# Altium schematic sheet Carte_Alim_TOP.SchDoc(Carte_Alim_TOP)
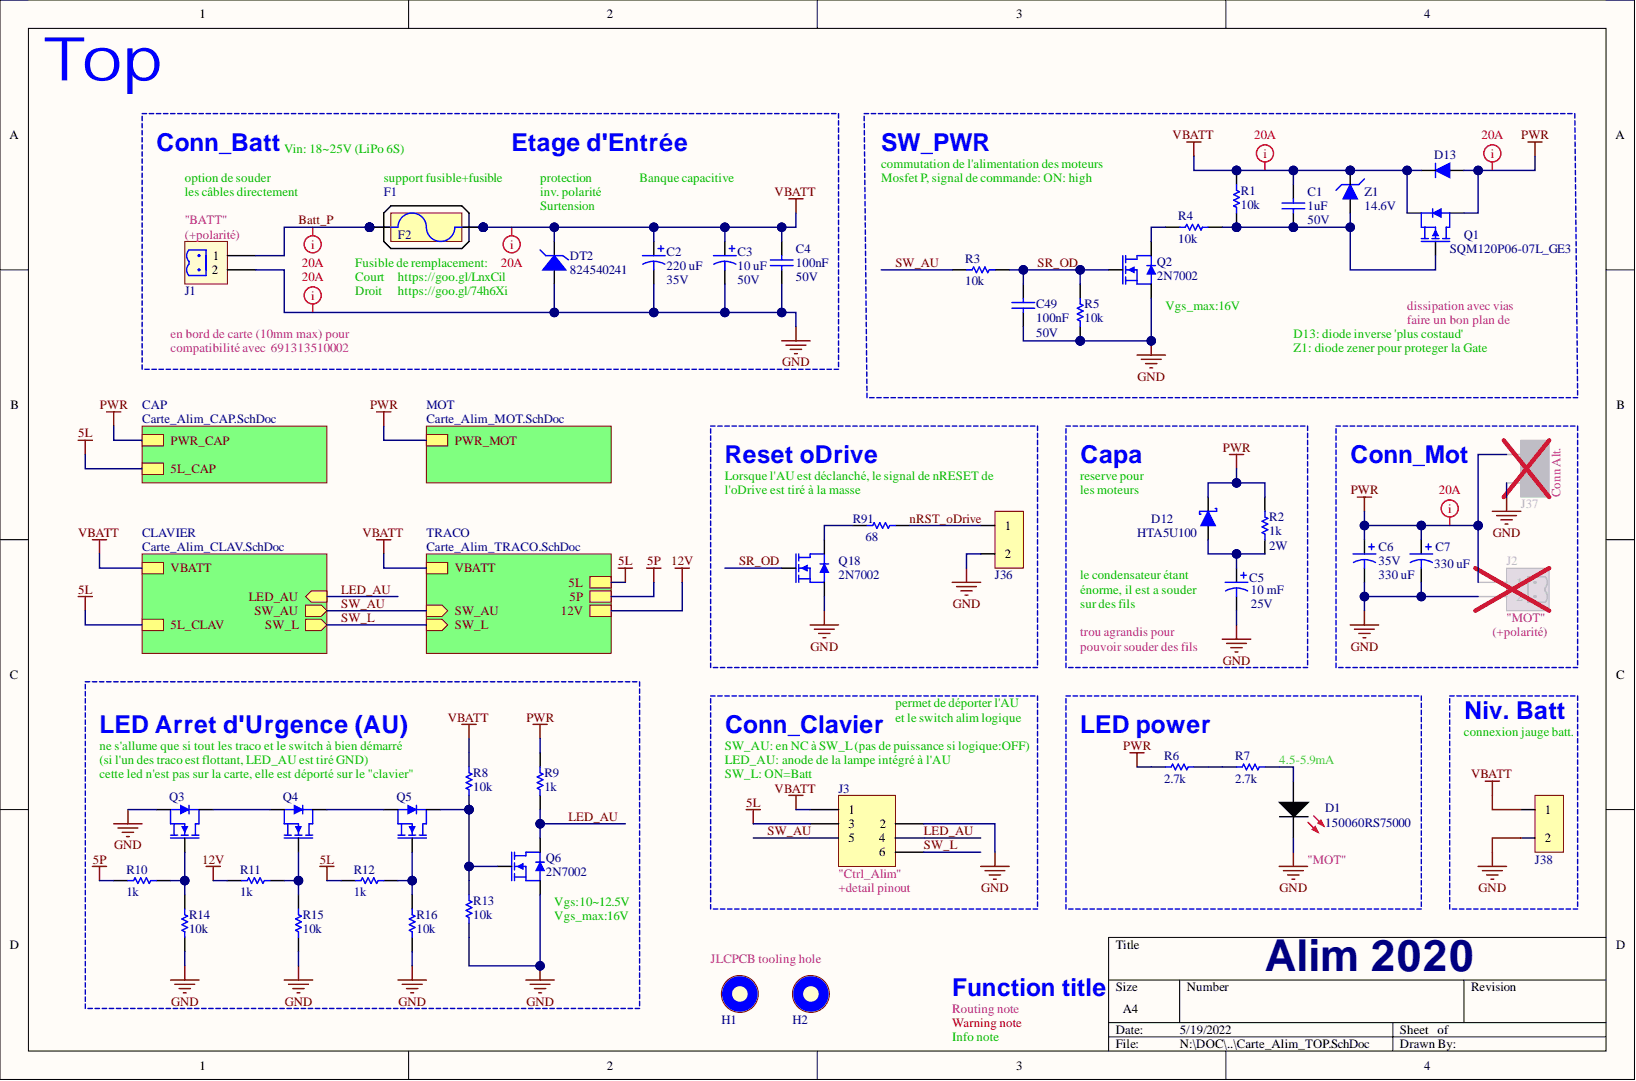
[diagram p0: Carte_Alim_TOP.SchDoc]

## Parts
C1  1uF  RatedVoltage: 50V  [WCAP-CSGP_0805, 2x1.25x1.25]
C2  220 uF  RatedVoltage: 35V  [WCAP-ATG8_8x11.5x3.5x0.5]
C3  10 uF  RatedVoltage: 50V  [WCAP-ATG8_5x11x2x0.5]
C4  100nF  RatedVoltage: 50V  [WCAP-CSGP_0603, 1.6x0.8x0.8]
C5  10 mF  RatedVoltage: 25V  [WCAP-ATG8_22x41x10x0.8]
C6  330 uF  RatedVoltage: 35V  [WCAP-ATG8_10x12.5x5x0.6]
C7  330 uF  [WCAP-ATG8_10x12.5x5x0.6]
C49  100nF  RatedVoltage: 50V  [WCAP-CSGP_0603, 1.6x0.8x0.8]
D1  150060RS75000  [SMCW_0603]
D12  HTA5U100  [SMA, DO-214AC]
D13  RS3J R6  [SMC, DO-214AB]  (value not drawn)
DT2  824540241  [SMC, DO-214AB]
F1  3557-2  [3557-2]  (value not drawn)
F2  0891030.NXS  (value not drawn)  (mechanical)
H1  1.152mm  [Tooling_hole_JLCPCB]  (value not drawn)  (no BOM)
H2  1.152mm  [Tooling_hole_JLCPCB]  (value not drawn)  (no BOM)
J1  value 2  [691311500102]  (hidden comment: 691311500102)
J2  value 2  [691311500102]  (hidden comment: 691311500102)
J3  value 6  [690367280676]  (hidden comment: 690367280676)
J36  22-03-2021  [22-03-2021]  (value not drawn)
J37  22-03-2021  [22-03-2021]  (value not drawn)
J38  22-03-2021  [22-03-2021]  (value not drawn)
Q1  SQM120P06-07L_GE3  [TO263AB, D2PAK]
Q2  2N7002  [SOT-23]
Q3  2N7002  [SOT-23]  (value not drawn)
Q4  2N7002  [SOT-23]  (value not drawn)
Q5  2N7002  [SOT-23]  (value not drawn)
Q6  2N7002  [SOT-23]
Q18  2N7002  [SOT-23]
R1  value 10k  [RESC1608X06N]  (hidden comment: RC0603FR-0710KL)
R2  1k  Power: 2W  [RESADV480W80L1200D390]
R3  value 10k  [RESC1608X06N]  (hidden comment: RC0603FR-0710KL)
R4  value 10k  [RESC1608X06N]  (hidden comment: RC0603FR-0710KL)
R5  value 10k  [RESC1608X06N]  (hidden comment: RC0603FR-0710KL)
R6  value 2.7k  [RESC2012X06N]  (hidden comment: RC0805FR-072K7L)
R7  value 2.7k  [RESC2012X06N]  (hidden comment: RC0805FR-072K7L)
R8  value 10k  [RESC1608X06N]  (hidden comment: RC0603FR-0710KL)
R9  value 1k  [RESC1608X06N]  (hidden comment: RC0603FR-071KL)
R10  value 1k  [RESC1608X06N]  (hidden comment: RC0603FR-071KL)
R11  value 1k  [RESC1608X06N]  (hidden comment: RC0603FR-071KL)
R12  value 1k  [RESC1608X06N]  (hidden comment: RC0603FR-071KL)
R13  value 10k  [RESC1608X06N]  (hidden comment: RC0603FR-0710KL)
R14  value 10k  [RESC1608X06N]  (hidden comment: RC0603FR-0710KL)
R15  value 10k  [RESC1608X06N]  (hidden comment: RC0603FR-0710KL)
R16  value 10k  [RESC1608X06N]  (hidden comment: RC0603FR-0710KL)
R91  value 68  [RESC1608X06N]  (hidden comment: RC0603FR-0768RL)
Z1  value 14.6V  [SOD-323]  (hidden comment: MM3Z15VST1G)

## Nets
5L: J3.3 R12.2
5P: R10.2
12V: R11.2
GND: C2.2 C3.2 C4.1 C5.2 C6.2 C7.2 C49.1 D1.1 DT2.1 J1.2 J2.2 J3.2 J36.2 J37.2 J38.2 Q2.2 Q3.2 Q6.2 Q18.2 R5.2 R13.1 R14.1 R15.1 R16.1
PWR: C6.1 C7.1 D12.2 D13.1 J2.1 J37.1 Q1.4 R2.1 R6.1 R9.2
VBATT: C1.2 C2.1 C3.1 C4.2 D13.2 DT2.2 F1.2 F1.4 J3.1 J38.1 Q1.3 R1.2 R8.2 Z1.2
Batt_P: F1.1 F1.3 J1.1
LED_AU: J3.4 Q6.3 R9.1
nRST_oDrive: J36.1 R91.2
SR_OD: C49.2 Q2.1 Q18.1 R3.2 R5.1
SW_AU: J3.5 R3.1
SW_L: J3.6
NetC1_1: C1.1 Q1.1 R1.1 R4.1 Z1.1
NetC5_1: C5.1 D12.1 R2.2
NetD1_2: D1.2 R7.1
NetQ2_3: Q2.3 R4.2
NetQ3_1: Q3.1 R10.1 R14.2
NetQ3_3: Q3.3 Q4.2
NetQ4_1: Q4.1 R11.1 R15.2
NetQ4_3: Q4.3 Q5.2
NetQ5_1: Q5.1 R12.1 R16.2
NetQ5_3: Q5.3 Q6.1 R8.1 R13.2
NetQ18_3: Q18.3 R91.1
NetR6_2: R6.2 R7.2

## Library data of the parts
C1 = WCAP-CSGP, 885012207103: CAP CER 1uF 50V X7R 0805; Manufacturer: Wurth Elektronik; CeramicType: X7R; Component Kind: Standard; ComponentLink1Description: Datasheet; ComponentLink1URL: http://katalog.we-online.com/pbs/datasheet/885012207103.pdf; Manufacturer Part Number: 885012207103; Size: 0805; Supplier 2: JLCPCB; Supplier Footprint 2: 0805; Supplier Part Number 2: C28323; Value: 1uF; Library Name: Condensateur.IntLib
C2 = WCAP-ATG8, 860010574011: CAP Alu 220 UF 20% 35 V; Manufacturer: Wurth Elektronik; Component Kind: Standard; ComponentLink1Description: Datasheet; ComponentLink1URL: http://katalog.we-online.de/pbs/datasheet/860010574011.pdf; Manufacturer Part Number: 860010574011; Size: 8mmx11.5mm; Value: 220 uF; Library Name: Condensateur.IntLib
C3 = WCAP-ATG8, 860010672009: CAP Alu 10 UF 20% 50 V; Manufacturer: Wurth Elektronik; Component Kind: Standard; ComponentLink1Description: Datasheet; ComponentLink1URL: http://katalog.we-online.de/pbs/datasheet/860010672009.pdf; Manufacturer Part Number: 860010672009; Size: 5mmx11mm; Value: 10 uF; Library Name: Condensateur.IntLib
C4 C49 = WCAP-CSGP, 885012206095: CAP CER 100nF 50V X7R 0603; Manufacturer: Wurth Elektronik; CeramicType: X7R; Component Kind: Standard; ComponentLink1Description: Datasheet; ComponentLink1URL: http://katalog.we-online.com/pbs/datasheet/885012206095.pdf; Manufacturer Part Number: 885012206095; Size: 0603; Supplier 2: JLCPCB; Supplier Footprint 2: 0603; Supplier Part Number 2: C14663; Value: 100nF; Library Name: Condensateur.IntLib
C5 = WCAP-ATG8, 860010483029: CAP Alu 10'000 UF 25 V; Manufacturer: Wurth Elektronik; Component Kind: Standard; ComponentLink1Description: Datasheet; ComponentLink1URL: http://katalog.we-online.de/pbs/datasheet/860010483029.pdf; Manufacturer Part Number: 860010483029; Size: 22x41mm; Value: 10 mF; Library Name: Condensateur.IntLib
C6 = WCAP-ATG8, 860010575012: CAP Alu 330 UF 20% 35 V; Manufacturer: Wurth Elektronik; Component Kind: Standard; ComponentLink1Description: Datasheet; ComponentLink1URL: http://katalog.we-online.de/pbs/datasheet/860010575012.pdf; Manufacturer Part Number: 860010575012; Size: 10mmx12.5mm; Value: 330 uF; Library Name: Condensateur.IntLib
C7 = WCAP-ATG8, 860010575012: CAP Alu 330 UF 20% 35 V; Manufacturer: Wurth Elektronik; RatedVoltage: 35V; Component Kind: Standard; ComponentLink1Description: Datasheet; ComponentLink1URL: http://katalog.we-online.de/pbs/datasheet/860010575012.pdf; Manufacturer Part Number: 860010575012; Size: 10mmx12.5mm; Value: 330 uF; Library Name: Condensateur.IntLib
D1 = WL-SMCW 0603  150060RS75000: SMD mono-color Chip LED, WL-SMCW, Red; Manufacturer: Wurth Elektronik; Value: Rouge; Component Kind: Standard; ComponentLink1Description: Datasheet; ComponentLink1URL: http://katalog.we-online.com/led/datasheet/150060RS75000.pdf; Library Name: Opto.IntLib; Manufacturer Part Number: 150060RS75000; Supplier 1: Farnell; Supplier 2: JLCPCB; Supplier Footprint 2: LED_0603; Supplier Part Number 1: 2322071; Supplier Part Number 2: C2286
D12 = HTA5U100: DIODE SCHOTTKY 100V 5A SMA (DO-214AC); Manufacturer: MULTICOMP; ContinuousForwardCurrent: 5A (Pk 50A); Component Kind: Standard; ComponentLink1Description: Datasheet; ComponentLink1URL: http://www.farnell.com/datasheets/2282884.pdf; Library Name: Diode.IntLib; Manufacturer Part Number: HTA5U100; Supplier 1: Farnell; Supplier Part Number 1: 2750942; Type: Schottky; Value: 100V
D13 = RS3J_R6: DIODE GEN PURP 600V 3A SMC; Manufacturer: TAIWAN SEMICONDUCTOR; Type: Standard; Component Kind: Standard; ComponentLink1Description: Datasheet; ComponentLink1URL: http://www.farnell.com/datasheets/2182655.pdf; ContinuousForwardCurrent: 3A; Library Name: Diode.IntLib; Manufacturer Part Number: RS3J R6; Supplier 1: Farnell; Supplier Part Number 1: 2677388; Value: 600V
DT2 = 824540241: WE-TVSP Power TVS Diode, Unidirectional, 1500 W, 24 VDC; Manufacturer: Wurth Electronics Inc.; Value: 24V; Component Kind: Standard; ComponentLink1Description: Datasheet; ComponentLink1URL: https://www.we-online.com/catalog/datasheet/824540241.pdf; Library Name: Diode.IntLib; Manufacturer Part Number: 824540241; Supplier 1: Farnell; Supplier Part Number 1: 2536699; Type: TVS
F1 = 3557-2: FUSE Holder 500V 30A PCB; Manufacturer: Keystone Electronics; Value: na; Component Kind: Standard; ComponentLink1Description: Datasheet; ComponentLink1URL: http://www.keyelco.com/product-pdf.cfm?p=296; Library Name: Fuse.IntLib; Manufacturer Part Number: 3557-2; Supplier 1: Farnell; Supplier Part Number 1: 2292904
F2 = 0891030.NXS: FUSE AUTO 30A 58VDC BLADE MINI; Manufacturer: Littelfuse Inc.; ComponentLink1Description: Datasheet; ComponentLink1URL: http://www.littelfuse.com/~/media/automotive/datasheets/fuses/passenger-car-and-commercial-vehicle/blade-fuses/littelfuse_lowprofilemini_datasheet.pdf; Library Name: Fuse.IntLib; Manufacturer Part Number: 0891030.NXS; Supplier 1: Digi-Key; Supplier Part Number 1: F4993-ND; Value: 30A
H1 H2 = HOLE_TOOLING: Tooling hole pour JLCPCB assembly; ComponentLink1Description: Manufacturer; ComponentLink1URL: https://support.jlcpcb.com/article/92-how-to-add-tooling-holes-for-smt-assembly-order; Library Name: Divers.IntLib
J1 J2 = 691311500102: WR-TBL 2p Series 311 - 5.08 mm Closed Vertical PCB Header; Manufacturer: Wurth Electronics Inc.; Component Kind: Standard; ComponentLink1Description: Datasheet; ComponentLink1URL: https://katalog.we-online.de/em/datasheet/6913115001xx.pdf; Manufacturer Part Number: 691311500102; Supplier 1: Farnell; Supplier Part Number 1: 1641978; Library Name: Connecteurs.IntLib
J3 = 690367280676: WR-MM 6p Female SMT Connector with Polarization; Manufacturer: Wurth Electronics Inc.; Component Kind: Standard; ComponentLink1Description: Datasheet; ComponentLink1URL: http://katalog.we-online.de/em/datasheet/69036728xx76.pdf; Manufacturer Part Number: 690367280676; Supplier 1: Farnell; Supplier Part Number 1: 1641849; Library Name: Connecteurs.IntLib
J36-J38 = 22-03-2021: CONN HEADER VERT 2POS 2.54MM; Manufacturer: MOLEX; Component Kind: Standard; ComponentLink1Description: Datasheet; ComponentLink1URL: http://www.farnell.com/datasheets/1791308.pdf; ComponentLink2Description: Drawings; ComponentLink2URL: http://www.farnell.com/cad/495071.pdf  http://www.farnell.com/cad/1533907.pdf  http://www.farnell.com/cad/1533907.pdf; Manufacturer Part Number: 22-03-2021; Supplier 1: Farnell; Supplier Part Number 1: 9731075; Library Name: Connecteurs.IntLib
Q1 = SQM120P06-07L_GE3: MOSFET P 60V 120A D2PAK; Manufacturer: VISHAY; Component Kind: Standard; ComponentLink1Description: Datasheet; ComponentLink1URL: http://www.vishay.com/docs/67026/sqm120p0.pdf; Library Name: Transistors.IntLib; Manufacturer Part Number: SQM120P06-07L_GE3; Supplier 1: Farnell; Supplier Part Number 1: 2617099
Q2-Q6 Q18 = 2N7002: MOSFET N 60V 115MA SOT23; Manufacturer: Changjiang Electronics Tech (CJ); Component Kind: Standard; ComponentLink1Description: Datasheet; ComponentLink1URL: https://datasheet.lcsc.com/szlcsc/1810151612_Changjiang-Electronics-Tech-CJ-2N7002_C8545.pdf; Library Name: Transistors.IntLib; Manufacturer Part Number: 2N7002; Supplier 1: Farnell; Supplier 2: JLCPCB; Supplier Footprint 2: SOT-23-3; Supplier Part Number 1: 1510761; Supplier Part Number 2: C8545
R1 R3-R5 R8 R13-R16 = RC0603FR-0710KL: RES SMD 10K OHM 1% 1/10W 0603; Manufacturer: Yageo; Power: 100mW; Vmax: 50V; Component Kind: Standard; ComponentLink1Description: Manufacturer; ComponentLink1URL: http://www.yageo.com/NewPortal/_en/index.jsp; ComponentLink2Description: Datasheet; ComponentLink2URL: http://www.farnell.com/datasheets/2167052.pdf; Manufacturer Part Number: RC0603FR-0710KL; Precision: ±1%; Supplier 1: Farnell; Supplier 2: JLCPCB; Supplier Footprint 2: 0603; Supplier Part Number 1: 9238603; Supplier Part Number 2: C25804; Type: Thick Film; Library Name: Resistance.IntLib
R2 = Generic_TH_Vert_Res: resistance traversante verticale générique; Manufacturer: MULTICOMP; Manufacturer Part Number: MCKNP02WJ0102A19; Precision: ±5%; Component Kind: Standard; Library Name: Resistance.IntLib; Supplier 1: Farnell; Supplier Part Number 1: 1903754; Type: Through Hole; Value: 1k
R6 R7 = RC0805FR-072K7L: RES SMD 2K7 OHM 1% 1/8W 0805; Manufacturer: Yageo; Power: 125mW; Vmax: 50V; Component Kind: Standard; ComponentLink1Description: Manufacturer; ComponentLink1URL: http://www.yageo.com/NewPortal/_en/index.jsp; ComponentLink2Description: Datasheet; ComponentLink2URL: http://www.farnell.com/datasheets/444164.pdf; Manufacturer Part Number: RC0805FR-072K7L; Precision: ±1%; Supplier 1: Farnell; Supplier 2: JLCPCB; Supplier Footprint 2: 0805; Supplier Part Number 1: 9237666; Supplier Part Number 2: C17530; Type: Thick Film; Library Name: Resistance.SchLib
R9-R12 = RC0603FR-071KL: RES SMD 1K OHM 1% 1/10W 0603; Manufacturer: Yageo; Power: 100mW; Vmax: 50V; Component Kind: Standard; ComponentLink1Description: Manufacturer; ComponentLink1URL: http://www.yageo.com/NewPortal/_en/index.jsp; ComponentLink2Description: Datasheet; ComponentLink2URL: http://www.farnell.com/datasheets/2167052.pdf; Manufacturer Part Number: RC0603FR-071KL; Precision: ±1%; Supplier 1: Farnell; Supplier 2: JLCPCB; Supplier Footprint 2: 0603; Supplier Part Number 1: 9238484; Supplier Part Number 2: C21190; Type: Thick Film; Library Name: Resistance.IntLib
R91 = RC0603FR-0768RL: RES SMD 68 OHM 1% 1/10W 0603; Manufacturer: Yageo; Power: 100mW; Vmax: 50V; Component Kind: Standard; ComponentLink1Description: Manufacturer; ComponentLink1URL: http://www.yageo.com/NewPortal/_en/index.jsp; ComponentLink2Description: Datasheet; ComponentLink2URL: http://www.farnell.com/datasheets/1868787.pdf; Manufacturer Part Number: RC0603FR-0768RL; Precision: ±1%; Supplier 1: Farnell; Supplier 2: JLCPCB; Supplier Footprint 2: 0603; Supplier Part Number 1: 9238344; Supplier Part Number 2: C27592; Type: Thick Film; Library Name: Resistance.IntLib
Z1 = MM3Z15VST1G: DIODE ZENER 14.6V 300mW SOD323; Manufacturer: ON Semiconductor; Component Kind: Standard; ComponentLink1Description: Datasheet; ComponentLink1URL: http://www.farnell.com/datasheets/2028649.pdf; Library Name: Diode.IntLib; Manufacturer Part Number: MM3Z15VST1G; Supplier 1: Farnell; Supplier Part Number 1: 2533369; Type: Zener
